# Altium schematic sheet alimentacao.SchDoc
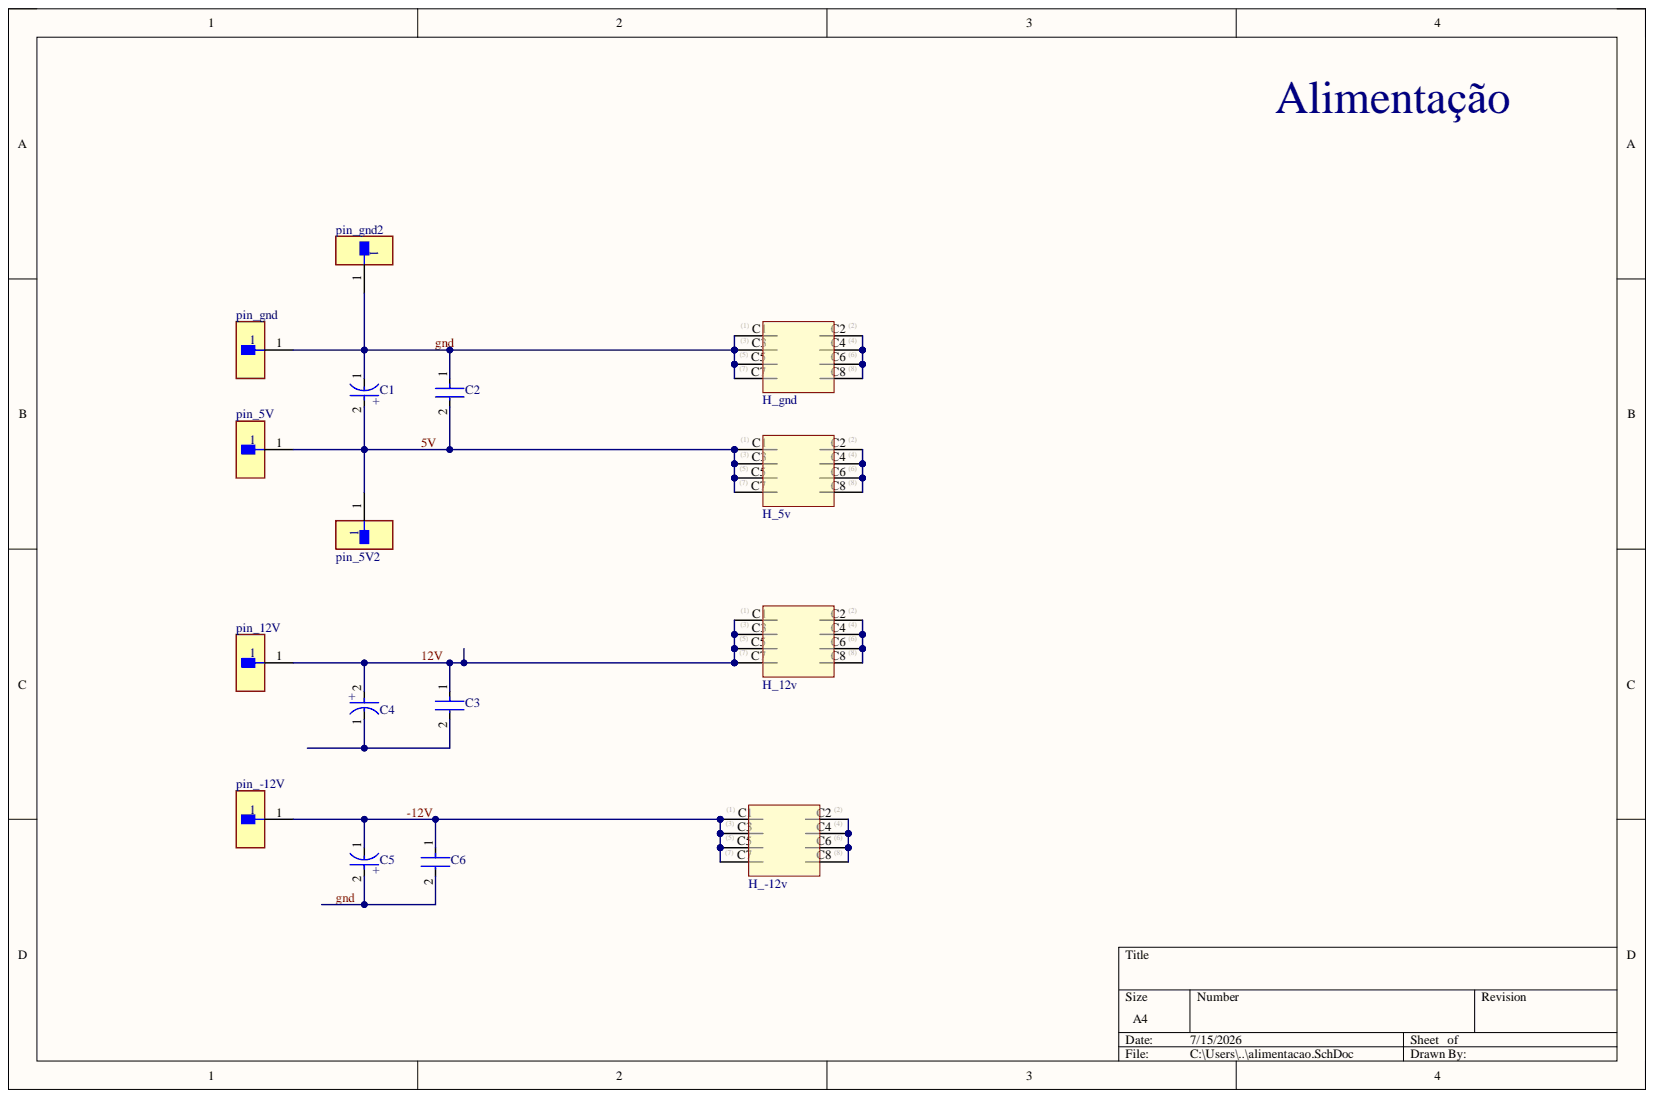
[diagram p0: alimentacao.SchDoc]

## Parts
C1  EEU-FM1H101BJ  [FP-FM8-MFG]  (value not drawn)
C2  RCER71H335K3K1H03B  [FP-RCE3M1-MFG]  (value not drawn)
C3  RCER71H335K3K1H03B  [FP-RCE3M1-MFG]  (value not drawn)
C4  EEU-FM1H101BJ  [FP-FM8-MFG]  (value not drawn)
C5  EEU-FM1H101BJ  [FP-FM8-MFG]  (value not drawn)
C6  RCER71H335K3K1H03B  [FP-RCE3M1-MFG]  (value not drawn)
H_5v  header 2x4  [header 2x4]  (value not drawn)
H_12v  header 2x4  [header 2x4]  (value not drawn)
H_-12v  header 2x4  [header 2x4]  (value not drawn)
H_gnd  header 2x4  [header 2x4]  (value not drawn)
pin_5V  TSW-101-07-L-S  [FP-TSW-101-07-L-S-MFG]  (value not drawn)
pin_5V2  TSW-101-07-L-S  [FP-TSW-101-07-L-S-MFG]  (value not drawn)
pin_12V  TSW-101-07-L-S  [FP-TSW-101-07-L-S-MFG]  (value not drawn)
pin_-12V  TSW-101-07-L-S  [FP-TSW-101-07-L-S-MFG]  (value not drawn)
pin_gnd  TSW-101-07-L-S  [FP-TSW-101-07-L-S-MFG]  (value not drawn)
pin_gnd2  TSW-101-07-L-S  [FP-TSW-101-07-L-S-MFG]  (value not drawn)

## Nets
5V: C1.2 C2.2 H_5v.1 H_5v.3 H_5v.5 H_5v.7 pin_5V.1 pin_5V2.1
12V: C3.1 C4.2 H_12v.1 H_12v.3 H_12v.5 H_12v.7 pin_12V.1
-12V: C5.1 C6.1 H_-12v.1 H_-12v.3 H_-12v.5 H_-12v.7 pin_-12V.1
gnd: C1.1 C2.1 C5.2 C6.2 H_gnd.1 H_gnd.3 H_gnd.5 H_gnd.7 pin_gnd.1 pin_gnd2.1
NetC3_2: C3.2 C4.1
NetH_5v_2: H_5v.2 H_5v.4 H_5v.6 H_5v.8
NetH_12v_2: H_12v.2 H_12v.4 H_12v.6 H_12v.8
NetH_-12v_2: H_-12v.2 H_-12v.4 H_-12v.6 H_-12v.8
NetH_gnd_2: H_gnd.2 H_gnd.4 H_gnd.6 H_gnd.8

## Library data of the parts
C1 C4 C5 = CMP-05427-000021-1: CAP ALUM 10uF 20% 50V RADIAL; Dissipation Factor: 0.1%; ESR (Equivalent Series Resistance): 61mR; Life (Hours): 3000Hour; Polarity: Polar; Ripple Current: 870mA; Capacitance: 10uF; Case/Package: Radial; Component Kind: Standard; Diameter: 8mm; Features: General Purpose; Height: 11.5mm; Height - Seated (Max): 0.512inch; Impedance: 61mR; Lead Free: Lead Free; Lead Pitch: 0.197inch; Length: 11.5mm; Library Name: Altium Content Vault; Max Operating Temperature: 105°C; Min Operating Temperature: -40°C; Mount: Through Hole; Package Quantity: 1000; Packaging: Ammo Pack; Radiation Hardening: No; Series: FM; Termination: Radial; Tolerance: 20%; Voltage Rating: 50V; Voltage Rating (DC): 50V
C2 C3 C6 = CMP-06035-028451-1: Cap Ceramic 100nF 50V X7R ±10% Radial 5mm +125°C Automotive Ammo Pack; Lead Diameter: 0.5mm; Lead/Base Style: Formed Leads; Capacitance: 100nF; Case/Package: Radial; Component Kind: Standard; Dielectric: X7R; Height: 5mm; Height - Seated (Max): 0.197inch; Lead Pitch: 0.197inch; Length: 0.217inch; Library Name: Altium Content Vault; Max Operating Temperature: 125°C; Min Operating Temperature: -55°C; Mount: Through Hole; Package Quantity: 500; Packaging: Bulk; Ratings: AEC-Q200; Resistor Type: Ceramic; Termination: Radial; Tolerance: 10%; Voltage Rating: 50V; Voltage Rating (DC): 50V; Width: 0.157inch
H_5v H_12v H_-12v H_gnd = header 2x4: header male 2x4; Component Kind: Standard; Library Name: Schlib1.SchLib; Parameter 1: header 2x4
pin_5V pin_5V2 pin_12V pin_-12V pin_gnd pin_gnd2 = CMP-02766-001775-1: CONN HEADER VERT 1POS; Gender: Male; Max Current Rating: 7.6A; Max Voltage Rating (AC): 550V; Number of Contacts: 1; Color: Black; Component Kind: Standard; Connector Type: Header; Contact Finish - Mating: GOLD; Contact Material: Bronze; Contact Mating Length: 0.23inch; Contact Plating: Gold; Contact Shape: Square; Contact Type: Male Pin; Depth: 2.54mm; Fastening Type: Pull; Flammability Rating: UL94 V-0; Housing Color: Black; Housing Material: Polyester; Insulation Color: Black; Insulation Material: PBT; Lead Free: Lead Free; Length: 2.54mm; Library Name: Altium Content Vault; Max Operating Temperature: 125°C; Min Operating Temperature: -55°C; Mount: Through Hole; Number of Positions: 1; Number of Rows: 1; Orientation: Straight; Packaging: Bulk; Radiation Hardening: No; Reach SVHC Compliant: Yes; RoHS Compliant: Yes; Series: TSW; Termination: Solder; Width: 2.54mm
